# Altium schematic sheet jtag.SchDoc(jtag)
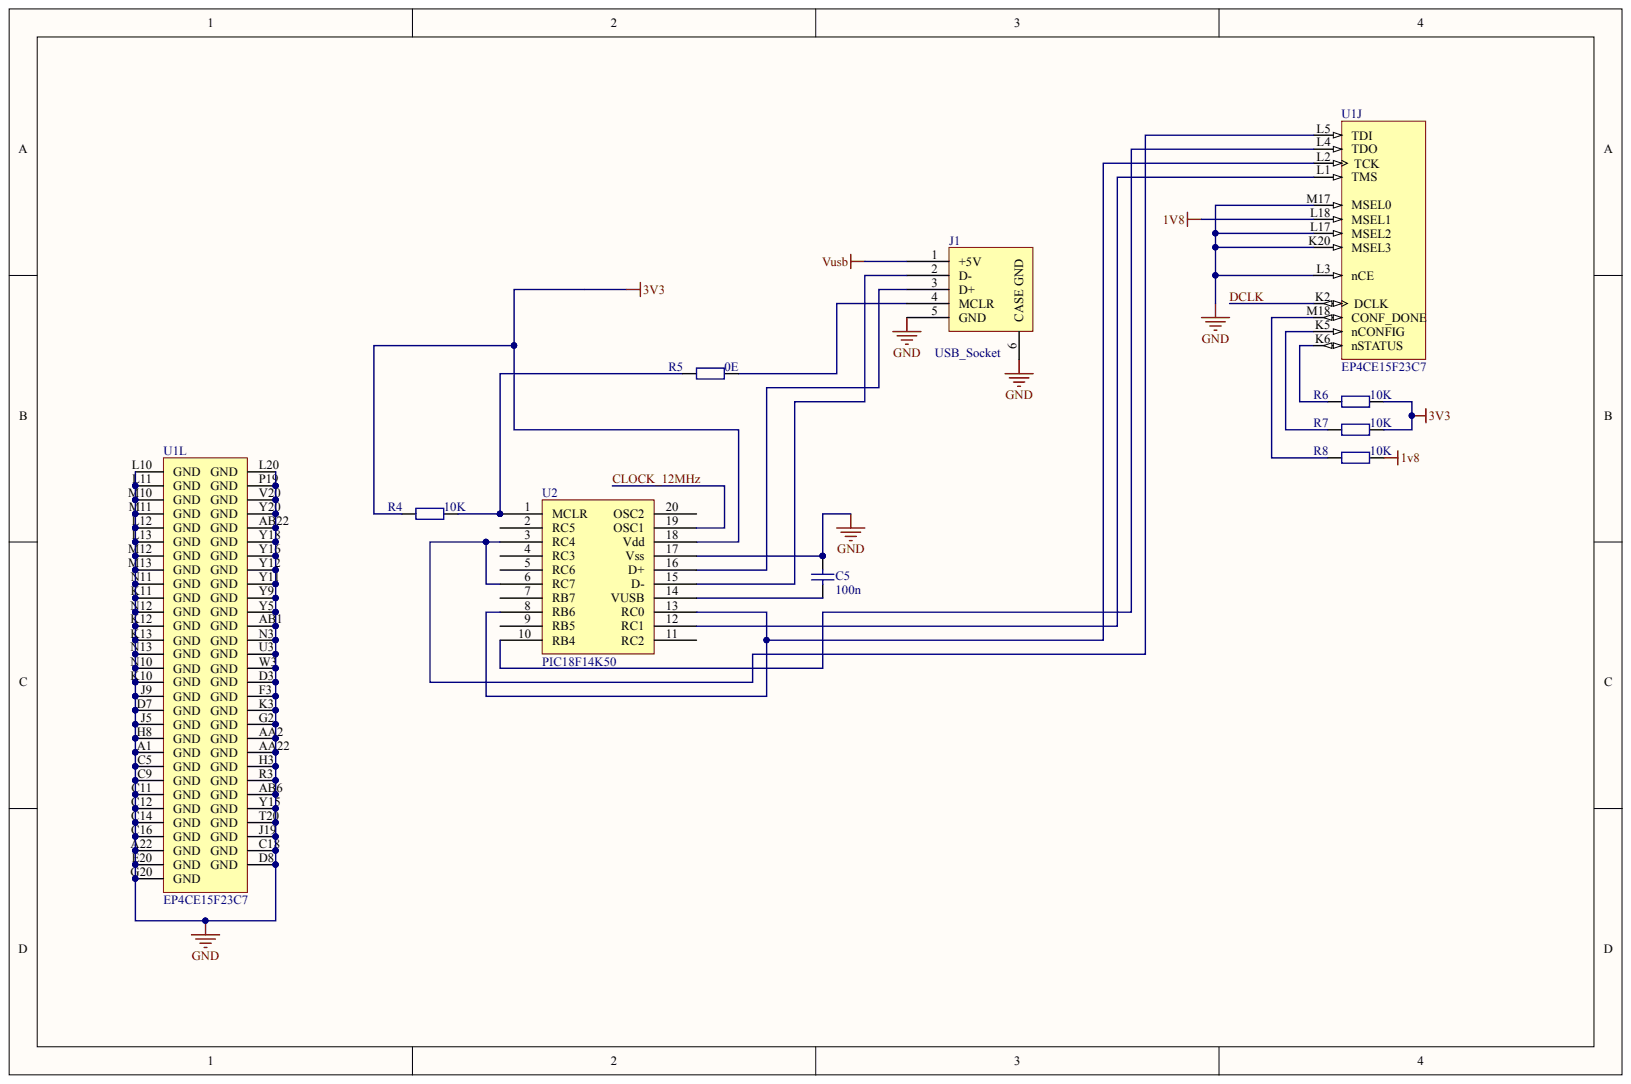
[diagram p0: jtag.SchDoc]

## Parts
C5  100n  [CAPC1005[0402]X50]
J1  USB_Socket  [USB_Socket_77X92X395]
R4  10K  [RESC1005[0402]X35]
R5  0E  [RESC1005[0402]X35]
R6  10K  [RESC1005[0402]X35]
R7  10K  [RESC1005[0402]X35]
R8  10K  [RESC1005[0402]X35]
U1  EP4CE15F23C7  [FBGA484_A-240]  (parts J,L)
U2  PIC18F14K50  [QFN_PIC18F14K50]

## Nets
1V8: R8.2 U1.L18(MSEL1)
3V3: R4.1 R6.2 R7.2 U2.18(Vdd)
GND: C5.2 J1.5(GND) J1.6(CASE_GND) U1.A1(GND) U1.A22(GND) U1.AA2(GND) U1.AA22(GND) U1.AB1(GND) U1.AB6(GND) U1.AB22(GND) U1.C5(GND) U1.C9(GND) U1.C11(GND) U1.C12(GND) U1.C14(GND) U1.C16(GND) U1.C18(GND) U1.D3(GND) U1.D7(GND) U1.D8(GND) U1.E20(GND) U1.F3(GND) U1.G2(GND) U1.G20(GND) U1.H3(GND) U1.H8(GND) U1.J5(GND) U1.J9(GND) U1.J19(GND) U1.K3(GND) U1.K10(GND) U1.K11(GND) U1.K12(GND) U1.K13(GND) U1.K20(MSEL3) U1.L3(nCE) U1.L10(GND) U1.L11(GND) U1.L12(GND) U1.L13(GND) U1.L17(MSEL2) U1.L20(GND) U1.M10(GND) U1.M11(GND) U1.M12(GND) U1.M13(GND) U1.M17(MSEL0) U1.N3(GND) U1.N10(GND) U1.N11(GND) U1.N12(GND) U1.N13(GND) U1.P19(GND) U1.R3(GND) U1.T20(GND) U1.U3(GND) U1.V20(GND) U1.W3(GND) U1.Y5(GND) U1.Y9(GND) U1.Y11(GND) U1.Y12(GND) U1.Y15(GND) U1.Y16(GND) U1.Y18(GND) U1.Y20(GND) U2.17(Vss)
VUSB: J1.1(+5V)
CLOCK_12MHZ: U2.19(OSC1)
DCLK: U1.K2(DCLK)
NetC5_1: C5.1 U2.14(VUSB)
NetJ1_2: J1.2(D-) U2.15(D-)
NetJ1_3: J1.3(D+) U2.16(D+)
NetJ1_4: J1.4(MCLR) R5.2
NetR4_2: R4.2 R5.1 U2.1(MCLR)
NetR6_1: R6.1 U1.K6(nSTATUS)
NetR7_1: R7.1 U1.K5(nCONFIG)
NetR8_1: R8.1 U1.M18(CONF_DONE)
NetU1_L1: U1.L1(TMS) U2.12(RC1)
NetU1_L2: U1.L2(TCK) U2.8(RB6) U2.13(RC0)
NetU1_L4: U1.L4(TDO) U2.10(RB4)
NetU1_L5: U1.L5(TDI) U2.3(RC4) U2.6(RC7)

Not connected: U2 2(RC5) 4(RC3) 5(RC6) 7(RB7) 9(RB5) 11(RC2) 20(OSC2)

## Library data of the parts
C5 = C: Capacitor; Modified_by: BEP1; Component Kind: Standard; Library Name: RC_SMD_3D.SchLib; Modified: 10.2008; Value: 100n
J1 U2 = Component_1: Component Kind: Standard
  J1: Library Name: USB_Socket.SchLib
  U2: Library Name: PIC.SchLib
R4-R8 = R: Resistor; Modified_by: BEP1; Component Kind: Standard; Library Name: RC_SMD_3D.SchLib; Modified: 10.2008
  R4 R6-R8: Value: 10K
  R5: Value: 0E
U1 = EP4CE15F23C7: Cyclone IV Family FPGA, 1.2V Core, 343 I/O Pins, 4 PLLs, 484-Pin FBGA, Speed Grade 7, Commercial Grade; Manufacturer: Altera; Code_JEDEC: MS-034-AAJ-1; Component Kind: Standard; ComponentLink1Description: Manufacturer URL; ComponentLink1URL: http://www.altera.com/; ComponentLink2Description: Handbook; ComponentLink2URL: http://www.altera.com/literature/hb/cyclone-iv/cyclone4-handbook.pdf; ComponentLink3Description: Pinout; ComponentLink3URL: http://www.altera.com/literature/lit-dp.jsp?category=cyc%204&showspreadsheet=y; ComponentLink4Description: Package Specification; ComponentLink4URL: http://www.altera.com/devicepackaging/04R00247-02.pdf; DatasheetVersion: ver1.6, Nov-2011; Library Name: Altera Cyclone IV E.IntLib; PackageDescription: 484-Pin FineLine Ball Grid Array (FBGA) - Wire Bond - A:2.40; PackageReference: FBGA484_A-240; PackageVersion: ver1.0, Jun-2011
